# Altium schematic sheet main.SchDoc(main)
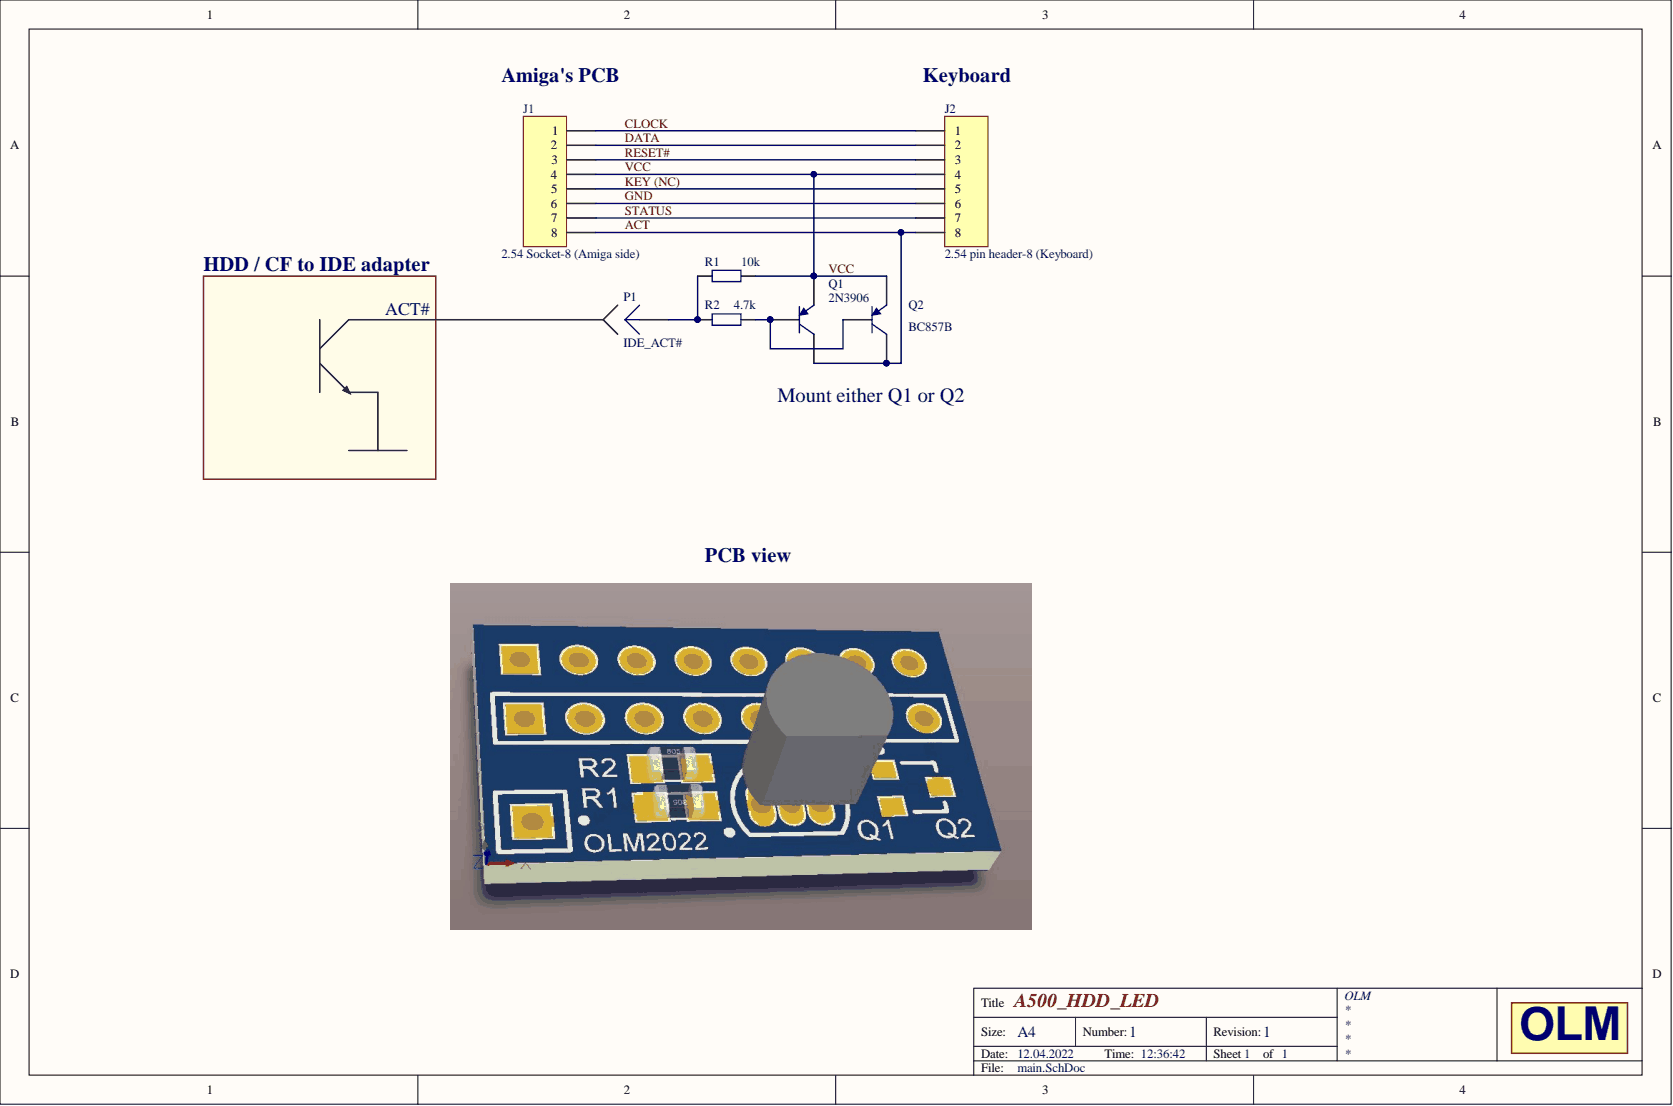
[diagram p0: main.SchDoc]

## Parts
J1  2.54 Socket-8 (Amiga side)  [HDR1X8]
J2  2.54 pin header-8 (Keyboard)  [HDR1X8]
P1  IDE_ACT#  [PIN1]
Q1  2N3906  [TO-92A]
Q2  BC857B  [SOT23]
R1  value 10k  [SM/R_0805]  (hidden comment: Резистор)
R2  value 4.7k  [SM/R_0805]  (hidden comment: Резистор)

## Nets
ACT: J1.8 J2.8 Q1.3 Q2.3
CLOCK: J1.1 J2.1
DATA: J1.2 J2.2
GND: J1.6 J2.6
KEY (NC): J1.5 J2.5
RESET#: J1.3 J2.3
STATUS: J1.7 J2.7
VCC: J1.4 J2.4 Q1.1 Q2.2 R1.1
NetP1_1: P1.1 R1.2 R2.1
NetQ1_2: Q1.2 Q2.1 R2.2

## Library data of the parts
J1 J2 = Header 8: Header, 8-Pin; Component Kind: Standard; LatestRevisionDate: 17-Jul-2002; LatestRevisionNote: Re-released for DXP Platform.; Library Name: Miscellaneous Connectors.IntLib; Signal Integrity: Connector; Publisher: Altium Limited
P1 = Plug: Component Kind: Standard; Description: Plug; LatestRevisionDate: 17-Jul-2002; LatestRevisionNote: Re-released for DXP Platform.; Library Name: Miscellaneous Connectors.IntLib; Signal Integrity: Connector; Publisher: Altium Limited
Q1 = 2N3906: PNP General Purpose Amplifier; Component Kind: Standard; ComponentLink1Description: Manufacturer Link; ComponentLink1URL: http://www.fairchildsemi.com/; ComponentLink2Description: Datasheet; ComponentLink2URL: http://www.fairchildsemi.com/ds/2N%2F2N3906.pdf; DatasheetVersion: 1997; LatestRevisionDate: 15-Jan-2003; LatestRevisionNote: PCB Footprint 'BCY-W3/D4.7' replaced; Library Name: Miscellaneous Devices.IntLib; PackageDescription: TO, Flat Index; 3 In-Line, Axial Leads; Body Dia. 4.5mm; Leads 0.51 x 0.48 mm (max); PackageReference: TO-92A; PackageVersion: Sep-1998; Published: 8-Jun-2000; Signal Integrity: 2N3906; Simulation: 2N3906; Publisher: Altium Limited
Q2 = BC857B: PNP General-purpose Transistor; Component Kind: Standard; ComponentLink1Description: Manufacturer Link; ComponentLink1URL: http://www.semiconductors.philips.com/; ComponentLink2Description: Datasheet; ComponentLink2URL: http://www.semiconductors.philips.com/acrobat/datasheets/BC856_BC857_BC858_6.pdf; DatasheetVersion: 4-Feb-2002; Library Name: Philips Discrete BJT - Low Power.IntLib; PackageDescription: SOT-23; 3 Leads; Body 3.0 x 2.5 mm, inc. leads (LxW max); PackageReference: SOT23; PackageVersion: May-1999; Published: 17-Sep-2003; Signal Integrity: BC857B; Simulation: QBC857B; Publisher: Altium Limited
R1 R2 = Res2: Resistor; Component Kind: Standard; LatestRevisionDate: 17-Jul-2002; LatestRevisionNote: Re-released for DXP Platform.; Library Name: Miscellaneous Devices.IntLib; PackageReference: AXIAL-0.4; Published: 8-Jun-2000; Signal Integrity: Res; Simulation: RESISTOR; Publisher: Altium Limited
